# Altium schematic sheet sch_lm3409.SchDoc
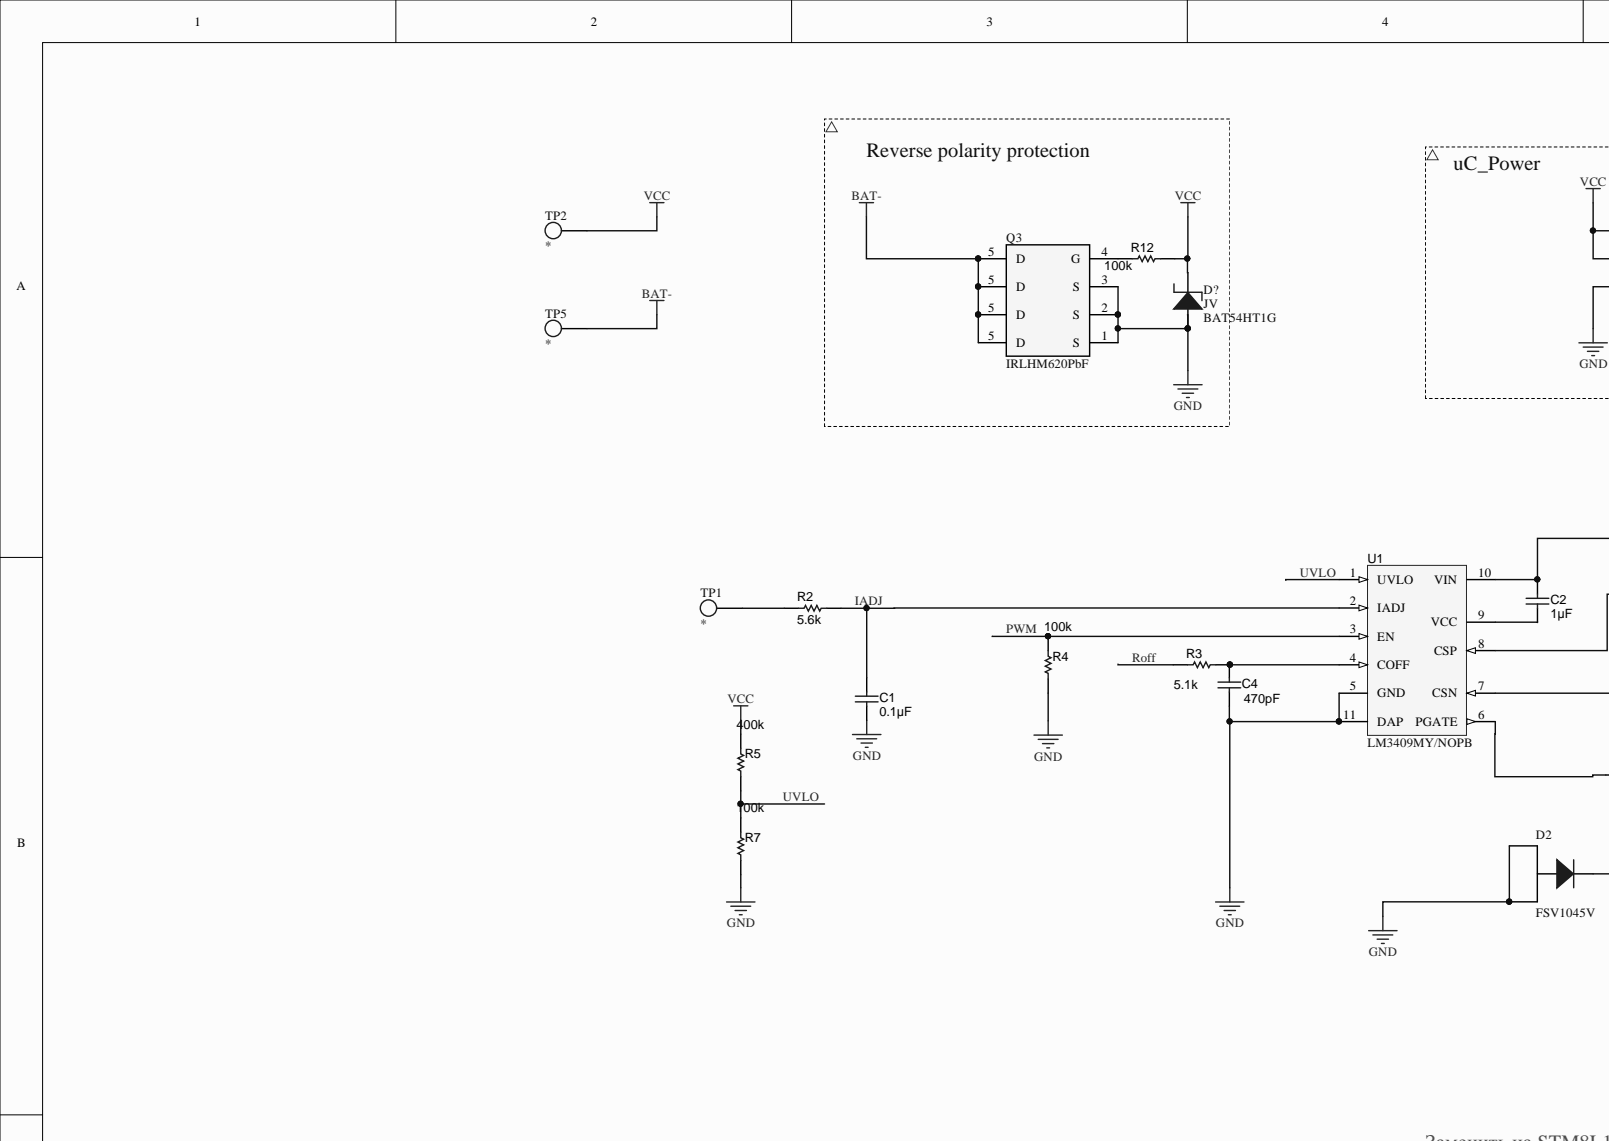
[diagram p0: sch_lm3409.SchDoc, top left]
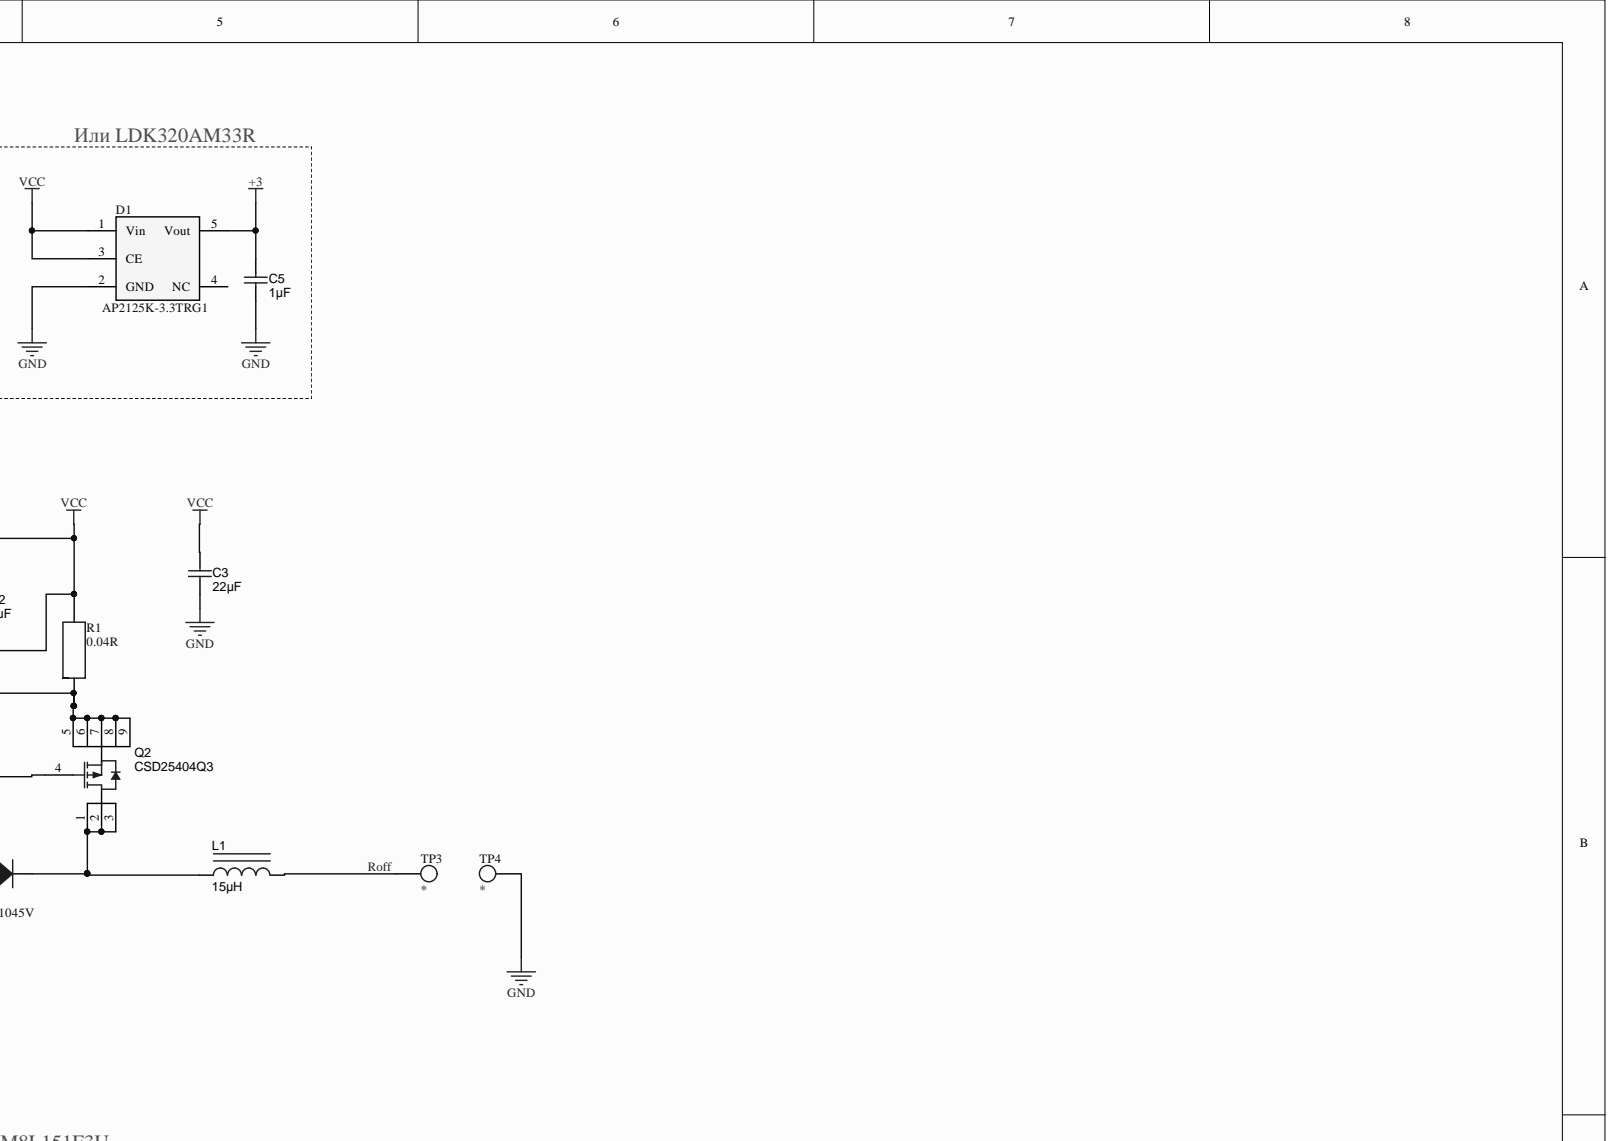
[diagram p1: sch_lm3409.SchDoc, top right]
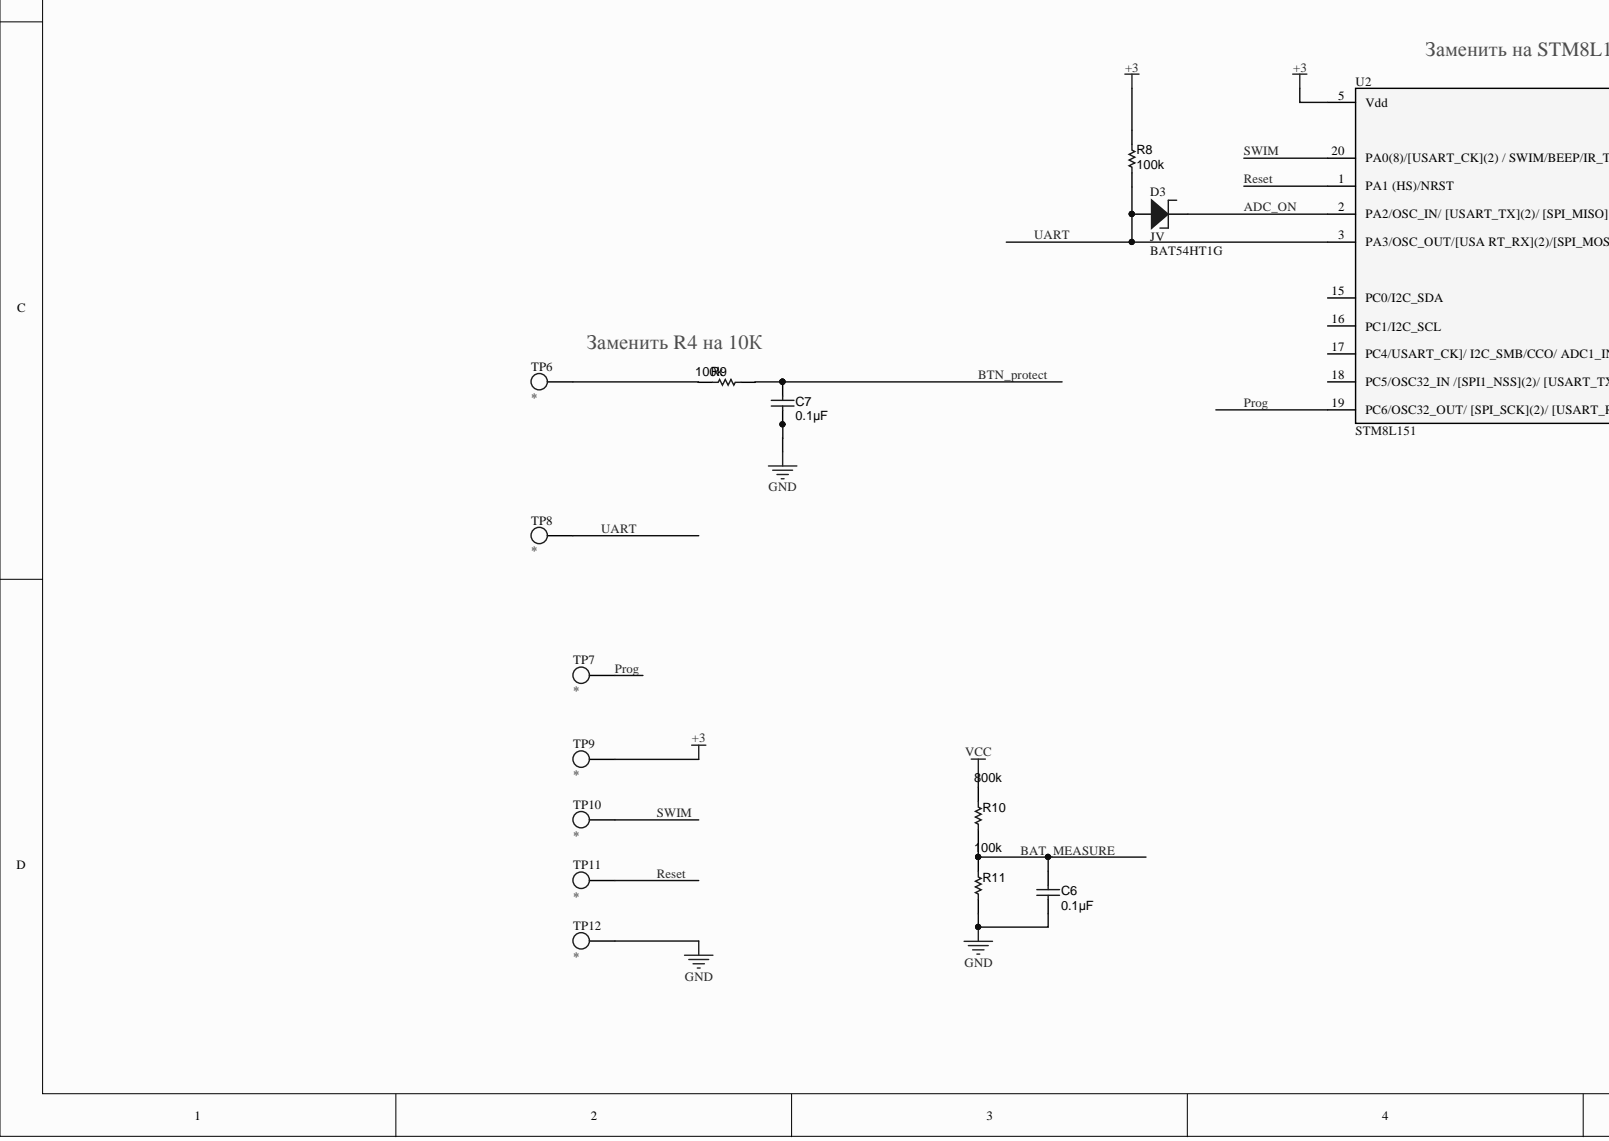
[diagram p2: sch_lm3409.SchDoc, bottom left]
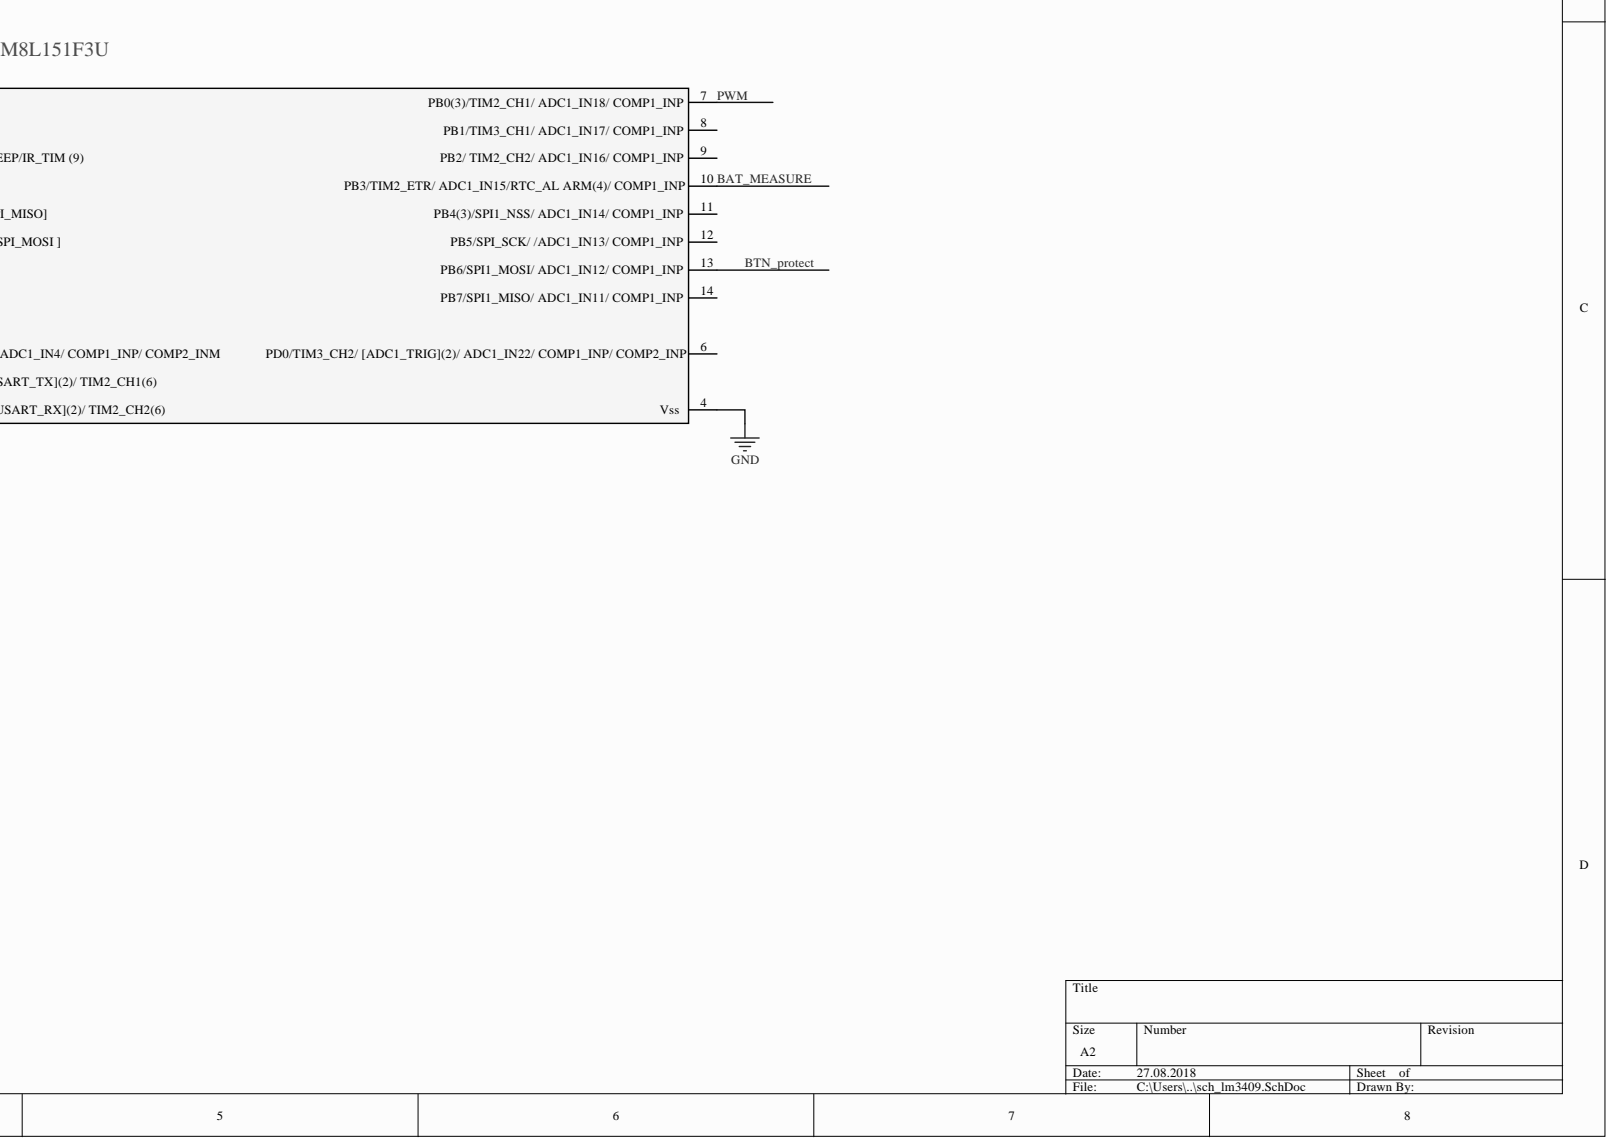
[diagram p3: sch_lm3409.SchDoc, bottom right]

## Parts
C1  ValueDisplayed: 0.1µF  [0402]  (hidden comment: C1005X7R1E104M050BB)
C2  ValueDisplayed: 1µF  [0603]  (hidden comment: C1608X7R1C105K080AC)
C3  ValueDisplayed: 22µF  [0805_HV]  (hidden comment: C2012X7R1H105K125AB)
C4  value 470pF  [0402]  (hidden comment: C1005X7R1E104M050BB)
C5  ValueDisplayed: 1µF  [0603]  (hidden comment: C1608X7R1C105K080AC)
C6  ValueDisplayed: 0.1µF  [0402]  (hidden comment: C1005X7R1E104M050BB)
C7  ValueDisplayed: 0.1µF  [0402]  (hidden comment: C1005X7R1E104M050BB)
D1  AP2125K-3.3TRG1  [SOT23-5]
D2  FSV1045V  [TO-277]
D3  JV  value BAT54HT1G  [SOD323]
D?  JV  value BAT54HT1G  [SOD323]
L1  ValueDisplayed: 15µH  [WE-TPC-LH]  (hidden comment: 744053150)
Q2  CSD25404Q3  [DQG0008A]
Q3  IRLHM620  value IRLHM620PbF  [PQFN-8 3.3x3.3]
R1  value 0.04R  [Resistor 1206]  (hidden comment: PAD)
R2  ValueDisplayed: 5.6k  [0402]  (hidden comment: CRCW0402470RJNED)
R3  value 5.1k  [0402]  (hidden comment: CRCW0402470RJNED)
R4  value 100k  [0402]  (hidden comment: CRCW0402470RJNED)
R5  value 400k  [0402]  (hidden comment: CRCW0402470RJNED)
R7  value 100k  [0402]  (hidden comment: CRCW0402470RJNED)
R8  ValueDisplayed: 100k  [0402]  (hidden comment: CRCW0402470RJNED)
R9  value 100k  [0402]  (hidden comment: CRCW0402470RJNED)
R10  value 800k  [0402]  (hidden comment: CRCW0402470RJNED)
R11  value 100k  [0402]  (hidden comment: CRCW0402470RJNED)
R12  value 100k  [0402]  (hidden comment: CRCW0402470RJNED)
TP1  (no value)  [TP 0.8mm]  (no BOM)
TP2  (no value)  [TP 0.8mm]  (no BOM)
TP3  (no value)  [TP 0.8mm]  (no BOM)
TP4  (no value)  [TP 0.8mm]  (no BOM)
TP5  (no value)  [TP 0.8mm]  (no BOM)
TP6  (no value)  [TP 0.8mm]  (no BOM)
TP7  (no value)  [TP 0.8mm]  (no BOM)
TP8  (no value)  [TP 0.8mm]  (no BOM)
TP9  (no value)  [TP 0.8mm]  (no BOM)
TP10  (no value)  [TP 0.8mm]  (no BOM)
TP11  (no value)  [TP 0.8mm]  (no BOM)
TP12  (no value)  [TP 0.8mm]  (no BOM)
U1  LM3409MY/NOPB  [MUC10A_N]
U2  STM8L151  value STM8L151F3U  [UFQFPN20 3x3x0.5]

## Nets
+3: C5.1 D1.5(Vout) R8.2 TP9.1 U2.5(Vdd)
BAT-: Q3.5(D) TP5.1
GND: C1.2 C3.2 C4.2 C5.2 C6.2 C7.2 D1.2(GND) D2.1 D2.2 D?.2 Q3.1(S) Q3.2(S) Q3.3(S) R4.1 R7.1 R11.1 TP4.1 TP12.1 U1.5(GND) U1.11(DAP) U2.4(Vss)
VCC: C2.1 C3.1 D1.1(Vin) D1.3(CE) D?.1 R1.2 R5.2 R10.2 R12.1 TP2.1 U1.8(CSP) U1.10(VIN)
ADC_ON: D3.1 U2.2(PA2/OSC_IN/_[USART_TX](2)/_[SPI_MISO])
BAT_MEASURE: C6.1 R10.1 R11.2 U2.10(PB3/TIM2_ETR/_ADC1_IN15/RTC_AL_ARM(4)/_COMP1_INP)
BTN_PROTECT: C7.1 R9.1 U2.13(PB6/SPI1_MOSI/_ADC1_IN12/_COMP1_INP)
IADJ: C1.1 R2.2 U1.2(IADJ)
PROG: TP7.1 U2.19(PC6/OSC32_OUT/_[SPI_SCK](2)/_[USART_RX](2)/_TIM2_CH2(6))
PWM: R4.2 U1.3(EN) U2.7(PB0(3)/TIM2_CH1/_ADC1_IN18/_COMP1_INP)
RESET: TP11.1 U2.1(PA1_(HS)/NRST)
ROFF: L1.2 R3.1 TP3.1
SWIM: TP10.1 U2.20(PA0(8)/[USART_CK](2)_/_SWIM/BEEP/IR_TIM_(9))
UART: D3.2 R8.1 TP8.1 U2.3(PA3/OSC_OUT/[USA_RT_RX](2)/[SPI_MOSI_])
UVLO: R5.1 R7.2 U1.1(UVLO)
NetC2_2: C2.2 U1.9(VCC)
NetC4_1: C4.1 R3.2 U1.4(COFF)
NetD2_3: D2.3 L1.1 Q2.1 Q2.2 Q2.3
NetQ2_4: Q2.4 U1.6(PGATE)
NetQ2_5: Q2.5 Q2.6 Q2.7 Q2.8 Q2.9 R1.1 U1.7(CSN)
NetQ3_4: Q3.4(G) R12.2
NetR2_1: R2.1 TP1.1
NetR9_2: R9.2 TP6.1

Not connected: D1 4(NC); U2 6(PD0/TIM3_CH2/_[ADC1_TRIG](2)/_ADC1_IN22/_COMP1_INP/_COMP2_INP) 8(PB1/TIM3_CH1/_ADC1_IN17/_COMP1_INP) 9(PB2/_TIM2_CH2/_ADC1_IN16/_COMP1_INP) 11(PB4(3)/SPI1_NSS/_ADC1_IN14/_COMP1_INP) 12(PB5/SPI_SCK/_/ADC1_IN13/_COMP1_INP) 14(PB7/SPI1_MISO/_ADC1_IN11/_COMP1_INP) 15(PC0/I2C_SDA) 16(PC1/I2C_SCL) 17(PC4/USART_CK]/_I2C_SMB/CCO/_ADC1_IN4/_COMP1_INP/_COMP2_INM) 18(PC5/OSC32_IN_/[SPI1_NSS](2)/_[USART_TX](2)/_TIM2_CH1(6))

## Library data of the parts
C1 C6 C7 = C1005X7R1E104M050BB: CAP, CERM, 0.1 µF, 25 V, +/- 20%, X7R, 0402; Manufacturer: TDK; AEC Category: Q200; AEC Qualified: N; Cap_F: 0.0000001; CompType: Capacitor; SizeArea_mm2: 0.5; SizeAreaWB_mm2: 3; SizeX_mm: 1; SizeZ_mm: 0.6; TaMax_C: 125; TaMin_C: -55; Tolerance_%: 20; U/M: EA; Value: 0.1uF; Value1: Unknown; Value2: 25V; VDC_V: 25; AEC Grade: N/A; Cap_Case: 0402; Component Kind: Standard; ComponentLink1Description: Datasheet link; ComponentLink1URL: http://product.tdk.com/en/catalog/datasheets/mlcc_commercial_general_en.pdf; LatestRevisionDate: 2017-02-09; MountType: SMT; PackageReference: 0402; PartNumber: C1005X7R1E104M050BB; Polarized: N; Published: 2008-06-19; RoHS: Y; Signal Integrity: Cap; Simulation: Cap; SizeY_mm: 0.5; Supplier 1: Digi-Key; Supplier 2: Mouser; Supplier 3: Farnell; Supplier Part Number 1: 445-7349-1-ND; Supplier Part Number 2: 810-C1005X7R1E104M; Supplier Part Number 3: 2354087; SymbolVersion: 2008-07-09; Technology: Ceramic; TempCo: X7R; Library Name: TIDA-01415.SCHLIB; Publisher: Texas Instruments
C2 C5 = C1608X7R1C105K080AC: CAP, CERM, 1 µF, 16 V, +/- 10%, X7R, 0603; Manufacturer: TDK; AEC Category: Q200; Cap_F: 0.000001; CompType: Capacitor; ESR_ohm: 0.0057; Polarized: N; SizeArea_mm2: 1.28; SizeAreaWB_mm2: 4.68; SizeX_mm: 1.6; SizeY_mm: 0.8; SizeZ_mm: 1; TaMax_C: 125; TaMin_C: -55; Tolerance_%: 10; U/M: EA; Value: 1uF; Value1: 0.0057 ohm; Value2: 16V; VDC_V: 16; AEC Qualified: ?; Cap_Case: 0603; Component Kind: Standard; ComponentLink1Description: Datasheet link; ComponentLink1URL: http://www.tdk.co.jp/tefe02/e412_c.pdf; LatestRevisionDate: 2016-10-05; MountType: SMT; PackageReference: 0603; PartNumber: C1608X7R1C105K080AC; Published: 2008-06-19; RoHS: Y; Signal Integrity: Cap; Simulation: Cap; Supplier 1: Digi-Key; Supplier 2: Mouser; Supplier 3: Farnell; Supplier Part Number 1: 445-1604-1-ND; Supplier Part Number 2: 810-C1608X7R1C105K; Supplier Part Number 3: 1907343; SymbolVersion: 2008-07-09; Technology: Ceramic; TempCo: X7R; Library Name: TIDA-01415.SCHLIB; Publisher: Texas Instruments
C3 = C2012X7R1H105K125AB: CAP, CERM, 1 µF, 50 V, +/- 10%, X7R, 0805; Manufacturer: TDK; AEC Category: Q200; AEC Qualified: N; Cap_Case: 0805; Cap_F: 0.000001; CompType: Capacitor; ESR_ohm: 0.008782; IRMS_A: 2.20488; SizeArea_mm2: 2.5; SizeAreaWB_mm2: 6.75; SizeX_mm: 2; SizeY_mm: 1.25; SizeZ_mm: 1.45; TaMax_C: 125; TaMin_C: -55; Tolerance_%: 10; U/M: EA; Value: 1uF; Value1: 0.008782 ohm; Value2: 50V; VDC_V: 50; AEC Grade: N/A; Component Kind: Standard; ComponentLink1Description: Datasheet link; ComponentLink1URL: https://product.tdk.com/en/search/capacitor/ceramic/mlcc/info?part_no=C2012X7R1H105K125AB; LatestRevisionDate: 2017-01-30; MountType: SMT; PackageReference: 0805; PartNumber: C2012X7R1H105K125AB; Polarized: N; Published: 2008-06-19; RoHS: Y; Signal Integrity: Cap; Simulation: Cap; Supplier 1: Digi-Key; Supplier 2: Mouser; Supplier Part Number 1: 445-7547-1-ND; Supplier Part Number 2: 810-C2012X7R1H105K; SymbolVersion: 2008-07-09; Technology: Ceramic; TempCo: X7R; Library Name: TIDA-01415.SCHLIB; Publisher: Texas Instruments
C4 = C1005X7R1E104M050BB: CAP, CERM, 0.1 µF, 25 V, +/- 20%, X7R, 0402; Manufacturer: TDK; AEC Category: Q200; AEC Qualified: N; Cap_F: 0.0000001; CompType: Capacitor; SizeArea_mm2: 0.5; SizeAreaWB_mm2: 3; SizeX_mm: 1; SizeZ_mm: 0.6; TaMax_C: 125; TaMin_C: -55; Tolerance_%: 20; U/M: EA; Value1: Unknown; Value2: 25V; VDC_V: 25; AEC Grade: N/A; Cap_Case: 0402; Component Kind: Standard; ComponentLink1Description: Datasheet link; ComponentLink1URL: http://product.tdk.com/en/catalog/datasheets/mlcc_commercial_general_en.pdf; LatestRevisionDate: 2017-02-09; MountType: SMT; PackageReference: 0402; PartNumber: C1005X7R1E104M050BB; Polarized: N; Published: 2008-06-19; RoHS: Y; Signal Integrity: Cap; Simulation: Cap; SizeY_mm: 0.5; Supplier 1: Digi-Key; Supplier 2: Mouser; Supplier 3: Farnell; Supplier Part Number 1: 445-7349-1-ND; Supplier Part Number 2: 810-C1005X7R1E104M; Supplier Part Number 3: 2354087; SymbolVersion: 2008-07-09; Technology: Ceramic; TempCo: X7R; ValueDisplayed: 470pF; Library Name: TIDA-01415.SCHLIB; Publisher: Texas Instruments
D1 = AP2125K-2.8TRG1: Component Name: IC,  LDO REGULATOR, AP2125K-3.3TRG1, SOT23-5; Component Kind: Standard; ComponentLink1Description: Datasheet; ComponentLink1URL: https://www.diodes.com/assets/Datasheets/AP2125.pdf; Description: AP2125K-3.3TRG1; Marking: AP2125K-3.3TRG1; Mounting type: SMD; Package: SOT23-5; Value: AP2125K-3.3TRG1; Library Name: Led2k1-x2-LCP.SCHLIB
D2 = CMP-03102-1: Component Name: Ultra Low VF schottky rectifier diodes, FSV1045V, TO-277; Component Kind: Standard; ComponentLink1Description: Datasheet; ComponentLink1URL: https://www.fairchildsemi.com/datasheets/FS/FSV1045V.pdf; Description: FSV1045V; Library Name: Diodes - Rectifiers - Single.SchLib; Marking: FSV1045V; Mounting type: SMD; Package: TO-277; Value: FSV1045V
D3 D? = CMP-00083-4: BAT54HT1G; Component Name: Schottky Barrier Diodes, BAT54HT1G, SOD-323; Component Kind: Standard; ComponentLink1Description: Datasheet; ComponentLink1URL: http://www.onsemi.ru.com/pub_link/Collateral/BAT54HT1-D.PDF; Library Name: Diodes.SchLib; Marking: JV; Mounting type: SMD; Package: SOD-323
L1 = 744053150: Inductor, Shielded Drum Core, Ferrite, 15 µH, 1.15 A, 0.07 ohm, SMD; Manufacturer: Wurth Elektronik; AEC Category: Q200; DCR_ohm: 0.07; Imax_A: 1.15; Ind_H: 15.0E-6; Irms: 1.38A @ 30C rise; Isat: 1.15A @ 35% inductance drop; SizeArea_mm2: 33.64; SizeAreaWB_mm2: 60.84; SizeX_mm: 5.8; SizeZ_mm: 2.8; Value: 15uH; Value2: 1.15A; AEC Qualified: ?; Component Kind: Standard; ComponentLink1Description: Datasheet link; ComponentLink1URL: http://www.we-online.com/eisos/pdf/744053150.pdf; CompType: Inductor; LatestRevisionDate: 2017-02-06; Material: Ferrite; MountType: SMT; PackageReference: WE-TPC-LH; PartNumber: 744053150; Published: 2008-06-26; RoHS: Y; Signal Integrity: Inductor; Simulation: Inductor; SizeY_mm: 5.8; Supplier 1: Digi-Key; Supplier 2: Mouser; Supplier Part Number 1: 732-1045-1-ND; Supplier Part Number 2: 710-744053150; SymbolVersion: 2008-07-23; Technology: Shielded Drum Core; Value1: 0.07 ohm; Library Name: TIDA-01415.SCHLIB; Publisher: Texas Instruments
Q2 = CSD25404Q3: MOSFET, P-CH, -20 V, -60 A, DQG0008A (VSON-CLIP-8); Alternate Manufacturer: None; Manufacturer: Texas Instruments; AEC Category: Q101; AEC Qualified: N; ChType: P; QgTyp_nC: 10.8; Rdson45_ohm: 0.0065; SizeArea_mm2: 10.89; SizeAreaWB_mm2: 18.49; SizeX_mm: 3.3; SizeZ_mm: 1.1; SymbolCreator: a0799628; U/M: EA; Value: -20V; Value1: 0.0065 ohm; Value2: 10.8 nC; Vgs_V: -12; Component Kind: Standard; ComponentLink1Description: Datasheet link; ComponentLink1URL: http://www.ti.com/lit/ds/symlink/csd25404q3.pdf; CompType: MOSFET; IdsMax_A: -60; LatestRevisionDate: 2017-06-02; LatestRevisionNote: Added parts.; MountType: SMT; PackageReference: DQG0008A; PartNumber: CSD25404Q3; Published: 2008-06-19; RoHS: Y; SizeY_mm: 3.3; SymbolVersion: 2014-08-22; VdsMax_V: -20; Library Name: PMP30284RevB_project.SCHLIB; Publisher: Texas Instruments
Q3 = IRLHM620PbF: Component Name: HEXFET Power MOSFET, IRLHM620PbF , PQFN-8 3x3MM; Component Kind: Standard; ComponentLink1Description: Datasheet; ComponentLink1URL: https://www.infineon.com/dgdl/irlhm620pbf.pdf?fileId=5546d462533600a4015356638be325a3; Description: IRLHM620PbF; Library Name: E-button.SCHLIB; Marking: IRLHM620; Mounting type: SMD; Package: PQFN-8 3x3
R1 = Resistors_1: Component Kind: Standard; Component Name: PAD; Description: PAD; Marking: PAD; Package: 1206; Library Name: Led2k1-x2-LCP.SCHLIB
R2 R8 = CRCW0402470RJNED: RES, 470, 5%, 0.063 W, 0402; Manufacturer: Vishay-Dale; AEC Category: Q200; CompType: Resistor; EIA_Code: E24; Power_W: 0.063; Res_ohm: 470; SizeArea_mm2: 0.5; SizeX_mm: 1; SizeZ_mm: 0.6; TempCo: 200 ppm/C; Tolerance_%: 5; U/M: EA; Value: 470; Value2: 0.063W; Value_Code: 470R; VDC_V: 50; AEC Qualified: ?; Component Kind: Standard; ComponentLink1Description: Datasheet link; ComponentLink1URL: http://www.vishay.com/docs/20035/dcrcwe3.pdf; LatestRevisionDate: 2017-01-28; MountType: SMT; PackageReference: 0402; PartNumber: CRCW0402470RJNED; Published: 2008-06-26; RoHS: Y; Signal Integrity: Resistor; Simulation: Resistor; SizeY_mm: 0.5; Supplier 1: Digi-Key; Supplier 2: Newark; Supplier 3: Mouser; Supplier Part Number 1: 541-470JCT-ND; Supplier Part Number 2: 59M6737; Supplier Part Number 3: 71-CRCW0402J-470-E3; SymbolVersion: 2009-05-29; Technology: Thick Film; Value1: 5%; Library Name: TIDA-01415.SCHLIB; Publisher: Texas Instruments
R3-R5 R7 R9-R12 = CRCW0402470RJNED: RES, 470, 5%, 0.063 W, 0402; Manufacturer: Vishay-Dale; AEC Category: Q200; CompType: Resistor; EIA_Code: E24; Power_W: 0.063; Res_ohm: 470; SizeArea_mm2: 0.5; SizeX_mm: 1; SizeZ_mm: 0.6; TempCo: 200 ppm/C; Tolerance_%: 5; U/M: EA; Value2: 0.063W; Value_Code: 470R; VDC_V: 50; AEC Qualified: ?; Component Kind: Standard; ComponentLink1Description: Datasheet link; ComponentLink1URL: http://www.vishay.com/docs/20035/dcrcwe3.pdf; LatestRevisionDate: 2017-01-28; MountType: SMT; PackageReference: 0402; PartNumber: CRCW0402470RJNED; Published: 2008-06-26; RoHS: Y; Signal Integrity: Resistor; Simulation: Resistor; SizeY_mm: 0.5; Supplier 1: Digi-Key; Supplier 2: Newark; Supplier 3: Mouser; Supplier Part Number 1: 541-470JCT-ND; Supplier Part Number 2: 59M6737; Supplier Part Number 3: 71-CRCW0402J-470-E3; SymbolVersion: 2009-05-29; Technology: Thick Film; Value1: 5%; Library Name: TIDA-01415.SCHLIB; Publisher: Texas Instruments
  R3: ValueDisplayed: 5.1k
  R4 R7 R9 R11 R12: ValueDisplayed: 100k
  R5: ValueDisplayed: 400k
  R10: ValueDisplayed: 800k
TP1-TP12 = CMP-00326-5: TP 0.8mm; Component Name: Connector, TP 0.8mm; ComponentLink1Description: Datasheet; Library Name: Connectors - Other.SchLib; Package: 0.8mm
U1 = LM3409MY/NOPB: PFET Buck Controller for High Power LED Drives, 10-pin eMSOP, Pb-Free; Manufacturer: Texas Instruments; CompType: MiscPower; PackageTopMarking: ZXTT*SXFB; PowerWise: Y; Code_JEDEC: MO-187-BAT; Component Kind: Standard; ComponentLink1Description: LM3409 Datasheet; ComponentLink1URL: http://www.national.com/ds/LM/LM3409.pdf; ComponentLink2Description: LM3409 Web Page; ComponentLink2URL: http://www.national.com/pf/LM/LM3409.html; ComponentLink3Description: MUC10A Package Page; ComponentLink3URL: http://www.national.com/packaging/folders/muc10a.html; DatasheetVersion: Apr-2010; LatestRevisionDate: 01-Oct-2010; LatestRevisionNote: Updated datasheet to version Apr-2010.; PackageDescription: Molded Mini-SO, Exposed Pad .118 x .118 x .034in Body, 10 Lead, .0197in Pitch; PackageReference: MUC10A; PackageVersion: revA, May-2006; PartNumber: LM3409MY/NOPB; PinCount: 10; Published: 1-Mar-2010; RoHS: Y; Supplier 1: Digi-Key; Supplier 2: Digi-Key; Supplier 3: Digi-Key; Supplier 4: Farnell; Supplier Part Number 1: LM3409MYTR-ND; Supplier Part Number 2: LM3409MYCT-ND; Supplier Part Number 3: LM3409MYDKR-ND; Supplier Part Number 4: 1735797; Library Name: TIDA-01415.SCHLIB; Publisher: Altium Limited
U2 = CMP-03101-1: STM8L151F3U; Component Name: IC, Microcontroller, STM8L151F3U, UFQFPN20; Component Kind: Standard; ComponentLink1Description: Datasheet; ComponentLink1URL: http://static6.arrow.com/aropdfconversion/39f28eae92662fce6231fc67e6fb213a085d3966/1988500997581432dm00027749.pdf; Library Name: Integrated_Library - 18.08.18 - 23.04.SchLib; Marking: STM8L151; Mounting type: SMD; Package: UFQFPN20
